# Altium schematic sheet Logic.SchDoc(Logic)
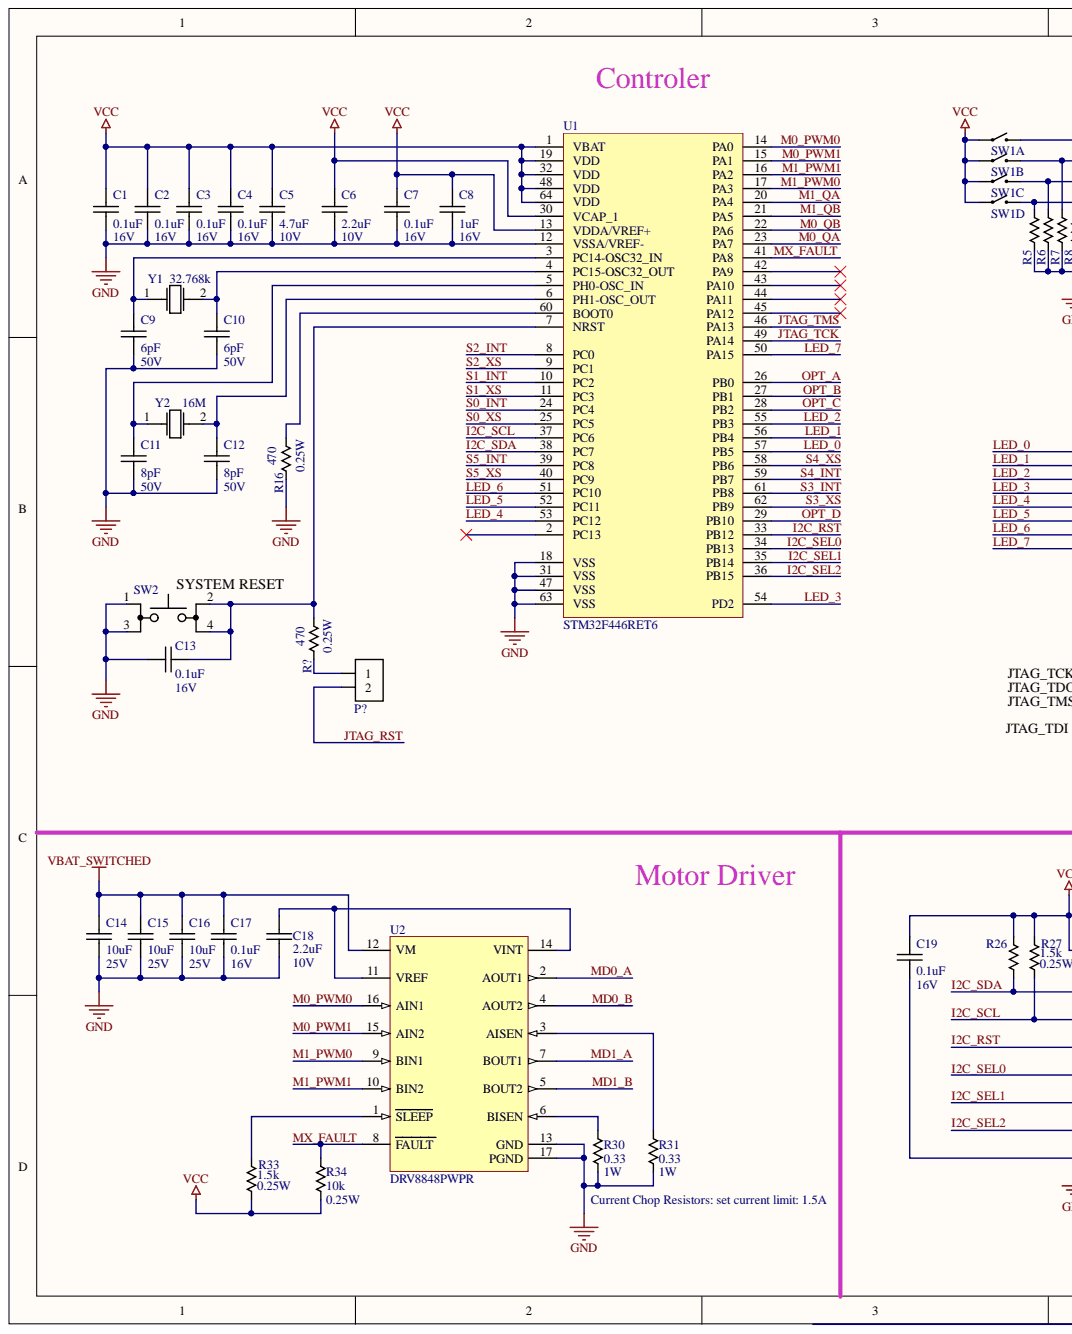
[diagram p0: Logic.SchDoc, left]
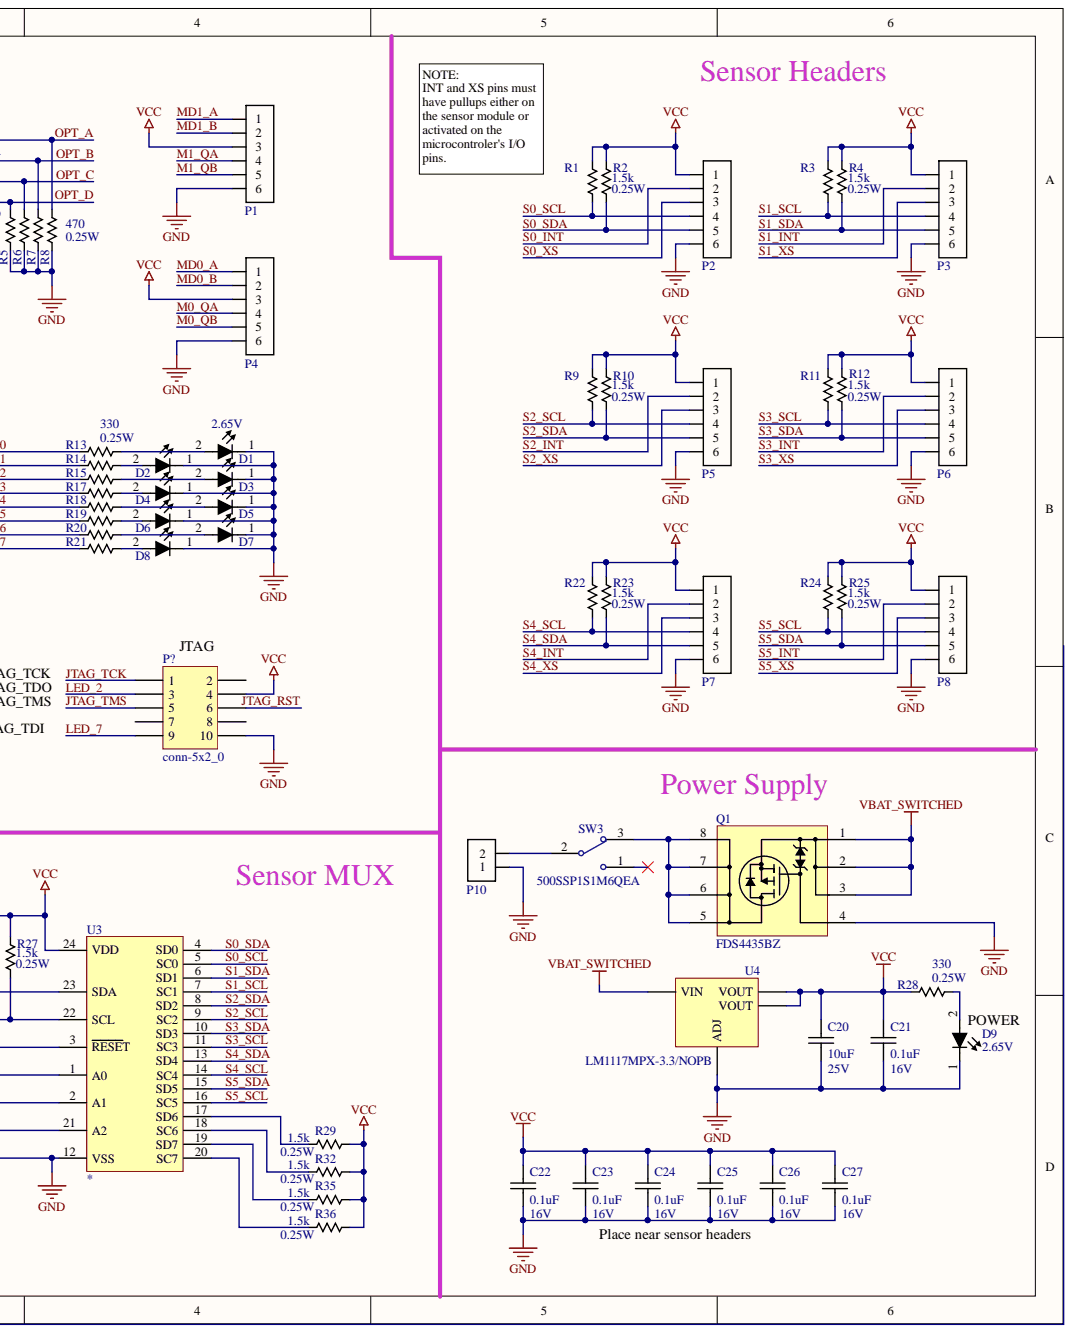
[diagram p1: Logic.SchDoc, right]

## Parts
C1  Voltage Rating: 16V  Capacitance: 0.1uF  [chip_0603]  (hidden comment: cap_single_np)
C2  Voltage Rating: 16V  Capacitance: 0.1uF  [chip_0603]  (hidden comment: cap_single_np)
C3  Voltage Rating: 16V  Capacitance: 0.1uF  [chip_0603]  (hidden comment: cap_single_np)
C4  Voltage Rating: 16V  Capacitance: 0.1uF  [chip_0603]  (hidden comment: cap_single_np)
C5  Voltage Rating: 10V  Capacitance: 4.7uF  [chip_0805]  (hidden comment: cap_single_np)
C6  Voltage Rating: 10V  Capacitance: 2.2uF  [chip_0603]  (hidden comment: cap_single_np)
C7  Voltage Rating: 16V  Capacitance: 0.1uF  [chip_0603]  (hidden comment: cap_single_np)
C8  Voltage Rating: 16V  Capacitance: 1uF  [chip_0805]  (hidden comment: cap_single_np)
C9  Voltage Rating: 50V  Capacitance: 6pF  [chip_0603]  (hidden comment: cap_single_np)
C10  Voltage Rating: 50V  Capacitance: 6pF  [chip_0603]  (hidden comment: cap_single_np)
C11  Voltage Rating: 50V  Capacitance: 8pF  [chip_0603]  (hidden comment: cap_single_np)
C12  Voltage Rating: 50V  Capacitance: 8pF  [chip_0603]  (hidden comment: cap_single_np)
C13  Voltage Rating: 16V  Capacitance: 0.1uF  [chip_0603]  (hidden comment: cap_single_np)
C14  Voltage Rating: 25V  Capacitance: 10uF  [chip_1206]  (hidden comment: cap_single_np)
C15  Voltage Rating: 25V  Capacitance: 10uF  [chip_1206]  (hidden comment: cap_single_np)
C16  Voltage Rating: 25V  Capacitance: 10uF  [chip_1206]  (hidden comment: cap_single_np)
C17  Voltage Rating: 16V  Capacitance: 0.1uF  [chip_0603]  (hidden comment: cap_single_np)
C18  Voltage Rating: 10V  Capacitance: 2.2uF  [chip_0603]  (hidden comment: cap_single_np)
C19  Voltage Rating: 16V  Capacitance: 0.1uF  [chip_0603]  (hidden comment: cap_single_np)
C20  Voltage Rating: 25V  Capacitance: 10uF  [chip_1206]  (hidden comment: cap_single_np)
C21  Voltage Rating: 16V  Capacitance: 0.1uF  [chip_0603]  (hidden comment: cap_single_np)
C22  Voltage Rating: 16V  Capacitance: 0.1uF  [chip_0603]  (hidden comment: cap_single_np)
C23  Voltage Rating: 16V  Capacitance: 0.1uF  [chip_0603]  (hidden comment: cap_single_np)
C24  Voltage Rating: 16V  Capacitance: 0.1uF  [chip_0603]  (hidden comment: cap_single_np)
C25  Voltage Rating: 16V  Capacitance: 0.1uF  [chip_0603]  (hidden comment: cap_single_np)
C26  Voltage Rating: 16V  Capacitance: 0.1uF  [chip_0603]  (hidden comment: cap_single_np)
C27  Voltage Rating: 16V  Capacitance: 0.1uF  [chip_0603]  (hidden comment: cap_single_np)
D1  Forward Voltage: 2.65V  [chip_0603]  (hidden comment: diode_4)
D2  diode_4  [chip_0603]  (value not drawn)
D3  diode_4  [chip_0603]  (value not drawn)
D4  diode_4  [chip_0603]  (value not drawn)
D5  diode_4  [chip_0603]  (value not drawn)
D6  diode_4  [chip_0603]  (value not drawn)
D7  diode_4  [chip_0603]  (value not drawn)
D8  diode_4  [chip_0603]  (value not drawn)
D9  Forward Voltage: 2.65V  [chip_0603]  (hidden comment: diode_4)
P1  conn-6x1_0  [JST_PHR_6_0]  (value not drawn)
P2  conn-6x1_0  [HDDR_6X1_0]  (value not drawn)
P3  conn-6x1_0  [HDDR_6X1_0]  (value not drawn)
P4  conn-6x1_0  [JST_PHR_6_0]  (value not drawn)
P5  conn-6x1_0  [HDDR_6X1_0]  (value not drawn)
P6  conn-6x1_0  [HDDR_6X1_0]  (value not drawn)
P7  conn-6x1_0  [HDDR_6X1_0]  (value not drawn)
P8  conn-6x1_0  [HDDR_6X1_0]  (value not drawn)
P10  conn-2x1_0  [PHOENIX_MKDS_5.08MM_2x1]  (value not drawn)
P?  conn-5x2_0  [CNC_TECH_3020-10-0100-XX]
P?  conn-2x1_0  [hddr_2x1_0]  (value not drawn)
Q1  Manufacturer PN: FDS4435BZ  [SO-8]  (hidden comment: p-mosfet-SSSGDDDD)
R1  res_single  [chip_0603]  (value not drawn)
R2  Resistance: 1.5k  Power Rating: 0.25W  [chip_0603]  (hidden comment: res_single)
R3  res_single  [chip_0603]  (value not drawn)
R4  Resistance: 1.5k  Power Rating: 0.25W  [chip_0603]  (hidden comment: res_single)
R5  res_single  [chip_0603]  (value not drawn)
R6  res_single  [chip_0603]  (value not drawn)
R7  res_single  [chip_0603]  (value not drawn)
R8  Power Rating: 0.25W  [chip_0603]  (hidden comment: res_single)
R9  res_single  [chip_0603]  (value not drawn)
R10  Resistance: 1.5k  Power Rating: 0.25W  [chip_0603]  (hidden comment: res_single)
R11  res_single  [chip_0603]  (value not drawn)
R12  Resistance: 1.5k  Power Rating: 0.25W  [chip_0603]  (hidden comment: res_single)
R13  Power Rating: 0.25W  [chip_0603]  (hidden comment: res_single)
R14  res_single  [chip_0603]  (value not drawn)
R15  res_single  [chip_0603]  (value not drawn)
R16  Power Rating: 0.25W  [chip_0603]  (hidden comment: res_single)
R17  res_single  [chip_0603]  (value not drawn)
R18  res_single  [chip_0603]  (value not drawn)
R19  res_single  [chip_0603]  (value not drawn)
R20  res_single  [chip_0603]  (value not drawn)
R21  res_single  [chip_0603]  (value not drawn)
R22  res_single  [chip_0603]  (value not drawn)
R23  Resistance: 1.5k  Power Rating: 0.25W  [chip_0603]  (hidden comment: res_single)
R24  res_single  [chip_0603]  (value not drawn)
R25  Resistance: 1.5k  Power Rating: 0.25W  [chip_0603]  (hidden comment: res_single)
R26  res_single  [chip_0603]  (value not drawn)
R27  Resistance: 1.5k  Power Rating: 0.25W  [chip_0603]  (hidden comment: res_single)
R28  Power Rating: 0.25W  [chip_0603]  (hidden comment: res_single)
R29  Resistance: 1.5k  Power Rating: 0.25W  [chip_0603]  (hidden comment: res_single)
R30  Resistance: 0.33  Power Rating: 1W  [chip_2512]  (hidden comment: res_single)
R31  Resistance: 0.33  Power Rating: 1W  [chip_2512]  (hidden comment: res_single)
R32  Resistance: 1.5k  Power Rating: 0.25W  [chip_0603]  (hidden comment: res_single)
R33  Resistance: 1.5k  Power Rating: 0.25W  [chip_0603]  (hidden comment: res_single)
R34  Resistance: 10k  Power Rating: 0.25W  [chip_0603]  (hidden comment: res_single)
R35  Resistance: 1.5k  Power Rating: 0.25W  [chip_0603]  (hidden comment: res_single)
R36  Resistance: 1.5k  Power Rating: 0.25W  [chip_0603]  (hidden comment: res_single)
R?  Power Rating: 0.25W  [chip_0603]  (hidden comment: res_single)
SW1  DIP_SW_4  [A6S-4104-H]  (value not drawn)  (parts A,B,C,D)
SW2  SW_1P1T_0  [KMR6]  (value not drawn)
SW3  Manufacturer PN: 500SSP1S1M6QEA  [E-Switch_500SSPyS1M6yEA]  (hidden comment: SW-SPDT)
U1  Manufacturer PN: STM32F446RET6  [LQFP64_0]  (hidden comment: STM32F446_64_0)
U2  DRV8848  Manufacturer PN: DRV8848PWPR  [HTSSOP-16_0]
U3  TCA9548A  [tssop-24_0]  (value not drawn)
U4  LM1117  Manufacturer PN: LM1117MPX-3.3/NOPB  [sot-223-4]
Y1  Frequency: 32.768k  [ABS25_OSC]  (hidden comment: osc_xtal_2p_0)
Y2  Frequency: 16M  [smd2-0]  (hidden comment: osc_xtal_2p_0)

## Nets
GND: C1.2 C2.2 C3.2 C4.2 C5.2 C6.2 C7.2 C8.2 C9.2 C10.2 C11.2 C12.2 C13.2 C14.2 C15.2 C16.2 C17.2 C18.2 C19.2 C20.2 C21.2 C22.2 C23.2 C24.2 C25.2 C26.2 C27.2 D1.1 D2.1 D3.1 D4.1 D5.1 D6.1 D7.1 D8.1 D9.1 P1.6 P2.6 P3.6 P4.6 P5.6 P6.6 P7.6 P8.6 P10.1 P?.10 Q1.4 R5.2 R6.2 R7.2 R8.2 R16.2 R30.2 R31.2 SW2.1 SW2.3 U1.12(VSSA/VREF-) U1.18(VSS) U1.31(VSS) U1.47(VSS) U1.63(VSS) U2.13(GND) U2.17(PGND) U3.12(VSS) U4.1(ADJ)
VBAT_SWITCHED: C14.1 C15.1 C16.1 C17.1 Q1.1 Q1.2 Q1.3 U2.12(VM) U4.3(VIN)
VCC: C1.1 C2.1 C3.1 C4.1 C5.1 C6.1 C7.1 C8.1 C19.1 C20.1 C21.1 C22.1 C23.1 C24.1 C25.1 C26.1 C27.1 P1.3 P2.1 P3.1 P4.3 P5.1 P6.1 P7.1 P8.1 P?.4 R1.1 R2.1 R3.1 R4.1 R9.1 R10.1 R11.1 R12.1 R22.1 R23.1 R24.1 R25.1 R26.1 R27.1 R28.1 R29.2 R32.2 R33.2 R34.2 R35.2 R36.2 SW1.1 SW1.2 SW1.3 SW1.4 U1.1(VBAT) U1.13(VDDA/VREF+) U1.19(VDD) U1.30(VCAP_1) U1.32(VDD) U1.48(VDD) U1.64(VDD) U3.24(VDD) U4.2(VOUT) U4.4(VOUT)
I2C_RST: U1.33(PB12) U3.3(RESET)
I2C_SCL: R27.2 U1.37(PC6) U3.22(SCL)
I2C_SDA: R26.2 U1.38(PC7) U3.23(SDA)
I2C_SEL0: U1.34(PB13) U3.1(A0)
I2C_SEL1: U1.35(PB14) U3.2(A1)
I2C_SEL2: U1.36(PB15) U3.21(A2)
JTAG_RST: P?.2 P?.6
JTAG_TCK: P?.1 U1.49(PA14)
JTAG_TMS: P?.5 U1.46(PA13)
LED_0: R13.1 U1.57(PB5)
LED_1: R14.1 U1.56(PB4)
LED_2: P?.3 R15.1 U1.55(PB3)
LED_3: R17.1 U1.54(PD2)
LED_4: R18.1 U1.53(PC12)
LED_5: R19.1 U1.52(PC11)
LED_6: R20.1 U1.51(PC10)
LED_7: P?.9 R21.1 U1.50(PA15)
M0_PWM0: U1.14(PA0) U2.16(AIN1)
M0_PWM1: U1.15(PA1) U2.15(AIN2)
M0_QA: P4.4 U1.23(PA7)
M0_QB: P4.5 U1.22(PA6)
M1_PWM0: U1.17(PA3) U2.9(BIN1)
M1_PWM1: U1.16(PA2) U2.10(BIN2)
M1_QA: P1.4 U1.20(PA4)
M1_QB: P1.5 U1.21(PA5)
MD0_A: P4.1 U2.2(AOUT1)
MD0_B: P4.2 U2.4(AOUT2)
MD1_A: P1.1 U2.7(BOUT1)
MD1_B: P1.2 U2.5(BOUT2)
MX_FAULT: R34.1 U1.41(PA8) U2.8(FAULT)
OPT_A: R8.1 SW1.8 U1.26(PB0)
OPT_B: R7.1 SW1.7 U1.27(PB1)
OPT_C: R6.1 SW1.6 U1.28(PB2)
OPT_D: R5.1 SW1.5 U1.29(PB10)
S0_INT: P2.2 U1.24(PC4)
S0_SCL: P2.4 R1.2 U3.5(SC0)
S0_SDA: P2.5 R2.2 U3.4(SD0)
S0_XS: P2.3 U1.25(PC5)
S1_INT: P3.2 U1.10(PC2)
S1_SCL: P3.4 R3.2 U3.7(SC1)
S1_SDA: P3.5 R4.2 U3.6(SD1)
S1_XS: P3.3 U1.11(PC3)
S2_INT: P5.2 U1.8(PC0)
S2_SCL: P5.4 R9.2 U3.9(SC2)
S2_SDA: P5.5 R10.2 U3.8(SD2)
S2_XS: P5.3 U1.9(PC1)
S3_INT: P6.2 U1.61(PB8)
S3_SCL: P6.4 R11.2 U3.11(SC3)
S3_SDA: P6.5 R12.2 U3.10(SD3)
S3_XS: P6.3 U1.62(PB9)
S4_INT: P7.2 U1.59(PB7)
S4_SCL: P7.4 R22.2 U3.14(SC4)
S4_SDA: P7.5 R23.2 U3.13(SD4)
S4_XS: P7.3 U1.58(PB6)
S5_INT: P8.2 U1.39(PC8)
S5_SCL: P8.4 R24.2 U3.16(SC5)
S5_SDA: P8.5 R25.2 U3.15(SD5)
S5_XS: P8.3 U1.40(PC9)
NetC9_1: C9.1 U1.3(PC14-OSC32_IN) Y1.1
NetC10_1: C10.1 U1.4(PC15-OSC32_OUT) Y1.2
NetC11_1: C11.1 U1.5(PH0-OSC_IN) Y2.1
NetC12_1: C12.1 U1.6(PH1-OSC_OUT) Y2.2
NetC13_1: C13.1 R?.1 SW2.2 SW2.4 U1.7(NRST)
NetC18_1: C18.1 U2.11(VREF) U2.14(VINT)
NetD1_2: D1.2 R13.2
NetD2_2: D2.2 R14.2
NetD3_2: D3.2 R15.2
NetD4_2: D4.2 R17.2
NetD5_2: D5.2 R18.2
NetD6_2: D6.2 R19.2
NetD7_2: D7.2 R20.2
NetD8_2: D8.2 R21.2
NetD9_2: D9.2 R28.2
NetP10_2: P10.2 SW3.2
NetP?_1: P?.1 R?.2
NetQ1_5: Q1.5 Q1.6 Q1.7 Q1.8 SW3.3
NetR16_1: R16.1 U1.60(BOOT0)
NetR29_1: R29.1 U3.17(SD6)
NetR30_1: R30.1 U2.6(BISEN)
NetR31_1: R31.1 U2.3(AISEN)
NetR32_1: R32.1 U3.18(SC6)
NetR33_1: R33.1 U2.1(SLEEP)
NetR35_1: R35.1 U3.19(SD7)
NetR36_1: R36.1 U3.20(SC7)
N00032:

Not connected: P? 7 8; SW3 1; U1 2(PC13) 42(PA9) 43(PA10) 44(PA11) 45(PA12)

## Library data of the parts
C1-C4 C7 C13 C17 C19 C21-C27 = CAP-00542: CAP, 0.1uF, ±10%, 16V, X7R, 0603; Manufacturer: Murata; Database Table Name: Capacitors$; Type: X7R; Tolerance: ±10%; Part Number: CAP-00542; Library Name: Capacitors.DbLib; Component Kind: Standard
C5 = CAP-00126: CAP, 4.7uF, 10%, 10V, X7R, 0805; Manufacturer PN: GRM21BR71A475KA73K; Manufacturer: Murata; Database Table Name: Capacitors$; Type: X7R; Tolerance: 10%; Part Number: CAP-00126; Library Name: Capacitors.DbLib; Component Kind: Standard
C6 C18 = CAP-00531: CAP, 2.2uF, ±10%, 10V, X7R, 0603; Manufacturer PN: GRM188R71A225KE15J; Manufacturer: Murata; Database Table Name: Capacitors$; Type: X7R; Tolerance: ±10%; Part Number: CAP-00531; Library Name: Capacitors.DbLib; Component Kind: Standard
C8 = CAP-00107: CAP, 1uF, 10%, 16V, X7R, 0805; Manufacturer PN: GRM21BR71C105KA01L; Manufacturer: Murata; Database Table Name: Capacitors$; Type: X7R; Tolerance: 10%; Part Number: CAP-00107; Library Name: Capacitors.DbLib; Component Kind: Standard
C9 C10 = CAP-00538: CAP, 6pF, ±10%, 50V, C0G, 0603; Database Table Name: Capacitors$; Type: C0G; Tolerance: ±10%; Part Number: CAP-00538; Library Name: Capacitors.DbLib; Component Kind: Standard
C11 C12 = CAP-00402: CAP, 8pF, ±0.1pF, 50V, C0G, 0603; Manufacturer PN: GRM1885C1H8R0BA01D; Manufacturer: Murata; Database Table Name: Capacitors$; Type: C0G; Tolerance: ±0.1pF; Part Number: CAP-00402; Library Name: Capacitors.DbLib; Component Kind: Standard
C14-C16 C20 = CAP-00539: CAP, 10uF, ±10%, 25V, X7R, 1206; Manufacturer PN: CL31B106KAHNNNE; Manufacturer: Samsung; Database Table Name: Capacitors$; Type: X7R; Tolerance: ±10%; Part Number: CAP-00539; Library Name: Capacitors.DbLib; Component Kind: Standard
D1 = DIO-00050: LED, Green, 0603, Low current, HELI2 Series; Manufacturer PN: APT1608LZGCK; Manufacturer: Kingbright; Reverse Breakdown Voltage: 5V; Power Rating: 120mW; Database Table Name: Diodes$; Current Rating: 30mA; Type: LED; Part Number: DIO-00050; Library Name: Diodes.DbLib; Cost: 0.58; Component Kind: Standard
D2-D8 = DIO-00050: LED, Green, 0603, Low current, HELI2 Series; Manufacturer PN: APT1608LZGCK; Manufacturer: Kingbright; Reverse Breakdown Voltage: 5V; Power Rating: 120mW; Forward Voltage: 2.65V; Database Table Name: Diodes$; Current Rating: 30mA; Type: LED; Part Number: DIO-00050; Library Name: Diodes.DbLib; Cost: 0.58; Component Kind: Standard
D9 = DIO-00049: LED, Blue, 0603, Low current, HELI2 Series; Manufacturer PN: APT1608LVBC/D; Manufacturer: Kingbright; Reverse Breakdown Voltage: 5V; Power Rating: 120mW; Database Table Name: Diodes$; Current Rating: 30mA; Type: LED; Part Number: DIO-00049; Library Name: Diodes.DbLib; Cost: 0.58; Component Kind: Standard
P1 P4 = CONN-00017: JST PHR 6x1; Positions per level: 6; Levels: 1; Database Table Name: Connectors$; Type: Header; Part Number: CONN-00017; Manufacturer: JST; Library Name: Connectors.DbLib; Component Kind: Standard
P2 P3 P5-P8 = CONN-00008: Header 6x1, 0.1"; Positions per level: 6; Levels: 1; Database Table Name: Connectors$; Part Number: CONN-00008; Library Name: Connectors.DbLib; Component Kind: Standard
P10 = CONN-00014: Term Blk, 2 Pos, 0.2", 10A, 14-30 AWG, Rising Cage Clamp; Manufacturer PN: 1729128; Manufacturer: Phoenix Contact; Positions per level: 2; Levels: 1; Database Table Name: Connectors$; Current: 10; Type: Terminal Block; Part Number: CONN-00014; Library Name: Connectors.DbLib; Component Kind: Standard
P? = CONN-00054: Header, 10-pin IDC, 2.54mm Pitch; Manufacturer PN: 3020-10-0100-00; Manufacturer: CNC Tech; Positions per level: 5; Levels: 2; Database Table Name: Connectors$; Type: Header; Part Number: CONN-00054; Library Name: Connectors.DbLib; Cost: 0.58; Component Kind: Standard
P? = CONN-00055: Header, 2x1, 0.1"; Manufacturer PN: TSW-102-07-F-S; Manufacturer: Samtec; Positions per level: 2; Levels: 1; Database Table Name: Connectors$; Type: Header; Part Number: CONN-00055; Library Name: Connectors.DbLib; Cost: 0.2; Component Kind: Standard
Q1 = XSTR-00033: XSTR PFET, 8.8A, 30V, 2.5W, SOIC-8; Manufacturer: ON Semi; Vgs/Vce: 2.1V; Database Table Name: Transistors$; Type: PFET; Part Number: XSTR-00033; Max Voltage: 30V; Max Power: 2.5W; Max Current: 8.8A; Library Name: Transistors.DbLib; Component Kind: Standard
R1 R3 R9 R11 R22 R24 R26 = RES-00284: RES SMD 1.5K OHM 1% 1/4W 0603; Manufacturer PN: ERJ-PA3F1501V; Manufacturer: Panasonic; Power Rating: 0.25W; Database Table Name: Resistors$; Tolerance: 1%; Resistance: 1.5k; Part Number: RES-00284; Library Name: Resistors.DbLib; Component Kind: Standard
R2 R4 R10 R12 R23 R25 R27 R29 R32 R33 R35 R36 = RES-00284: RES SMD 1.5K OHM 1% 1/4W 0603; Manufacturer PN: ERJ-PA3F1501V; Manufacturer: Panasonic; Database Table Name: Resistors$; Tolerance: 1%; Part Number: RES-00284; Library Name: Resistors.DbLib; Component Kind: Standard
R5-R7 = RES-00236: RES SMD 470 OHM 1% 1/4W 0603; Manufacturer PN: ERJ-PA3F4700V; Manufacturer: Panasonic; Resistance: 470; Power Rating: 0.25W; Database Table Name: Resistors$; Tolerance: 1%; Part Number: RES-00236; Library Name: Resistors.DbLib; Component Kind: Standard
R8 R16 R? = RES-00236: RES SMD 470 OHM 1% 1/4W 0603; Manufacturer PN: ERJ-PA3F4700V; Manufacturer: Panasonic; Resistance: 470; Database Table Name: Resistors$; Tolerance: 1%; Part Number: RES-00236; Library Name: Resistors.DbLib; Component Kind: Standard
R13 R28 = RES-00221: RES SMD 330 OHM 1% 1/4W 0603; Manufacturer PN: ERJ-PA3F3300V; Manufacturer: Panasonic; Resistance: 330; Database Table Name: Resistors$; Tolerance: 1%; Part Number: RES-00221; Library Name: Resistors.DbLib; Component Kind: Standard
R14 R15 R17-R21 = RES-00221: RES SMD 330 OHM 1% 1/4W 0603; Manufacturer PN: ERJ-PA3F3300V; Manufacturer: Panasonic; Database Table Name: Resistors$; Tolerance: 1%; Part Number: RES-00221; Library Name: Resistors.DbLib; Component Kind: Standard
R30 R31 = RES-00692: RES SMD 0.33 OHM 1% 1W 2512; Manufacturer PN: ERJ-1TRQFR33U; Manufacturer: Panasonic; Database Table Name: Resistors$; Tolerance: 1%; Part Number: RES-00692; Library Name: Resistors.DbLib; Cost: 0.64; Component Kind: Standard
R34 = RES-00306: RES SMD 10K OHM 1% 1/4W 0603; Manufacturer PN: ERJ-PA3F1002V; Manufacturer: Panasonic; Database Table Name: Resistors$; Tolerance: 1%; Part Number: RES-00306; Library Name: Resistors.DbLib; Cost: 0.144; Component Kind: Standard
SW1 = MISC-00026: DIP Switch, SMD, 25mA, 24V; Manufacturer: Omron; Database Table Name: Misc$; Part Number: MISC-00026; Manufacturer PN: A6S-4104-H; Library Name: Misc.DbLib; Cost: 1.51; Component Kind: Standard
SW2 = MISC-00024: Switch, SPST, Tactile, 32V 50mA; Manufacturer PN: KMR621NG LFS; Manufacturer: C&K; Database Table Name: Misc$; Part Number: MISC-00024; Library Name: Misc.DbLib; Cost: 0.33; Component Kind: Standard
SW3 = MISC-00033: Switch, SPDT, 120VAC/28VDC, 5A, Horizontal Slide Switch; Manufacturer: E-Switch; Database Table Name: Misc$; Current Rating: *A; Part Number: MISC-00033; Library Name: Misc.DbLib; Cost: 3.93; ComponentLink1URL: http://www.apem.com; ComponentLink1Description: Manufacturer Link; Component Kind: Standard
U1 = UCTRL-00002: STM32F4 Series, 32-bit ARM M4, 180MHz, LQFP64; Manufacturer: ST; Database Table Name: Microcontrollers$; Part Number: UCTRL-00002; Library Name: Microcontrollers.DbLib; Component Kind: Standard
U2 = MISC-00022: IC, Motor Driver, Quad Half-Bridge, 4-18V; Manufacturer: TI; Database Table Name: Misc$; Part Number: MISC-00022; Library Name: Misc.DbLib; Cost: 1.53; Component Kind: Standard
U3 = IFACE-00001: I2C 8-Channel Mux with Reset, TCA9548A; Manufacturer: TI; Database Table Name: Interfaces$; Part Number: IFACE-00001; Manufacturer PN: TCA9548A; Library Name: Interfaces.DbLib; Cost: 1.55; Component Kind: Standard
U4 = PWREG-00018: REG, Linear, Fixed 3V3, 800mA, SOT-223; Manufacturer: TI; Voltage Range Out: 3.3V; Voltage Range In: 4.5 - 15V; Database Table Name: Power Reg$; Reg Type: Linear; Part Number: PWREG-00018; Max Current: 800mA; Library Name: Power Reg.DbLib; Fixed/Adj: Fixed; Cost: 1.14; Component Kind: Standard
Y1 = OSC-00003: XTAL, 32.768kHz, 6pF, 20ppm; Manufacturer PN: ABS25-32.768KHZ-6-T; Manufacturer: Abracon; Stability: *ppm; ESR: 50k; Database Table Name: Oscillators$; Tolerance: 20ppm; Part Number: OSC-00003; Load Capacitance: 6pF; Library Name: Oscillators.DbLib; Component Kind: Standard
Y2 = OSC-00000: XTAL, 16MHz, 8pF, 50, 20ppm; Manufacturer PN: NX5032GA-16MHZ-STD-CSK-4; Manufacturer: NDK; Stability: 30ppm; ESR: 50; Database Table Name: Oscillators$; Tolerance: 20ppm; Part Number: OSC-00000; Load Capacitance: 8pF; Library Name: Oscillators.DbLib; Component Kind: Standard
